# Altium schematic sheet Arduino-8x8 RGB Matrix_1.SchDoc
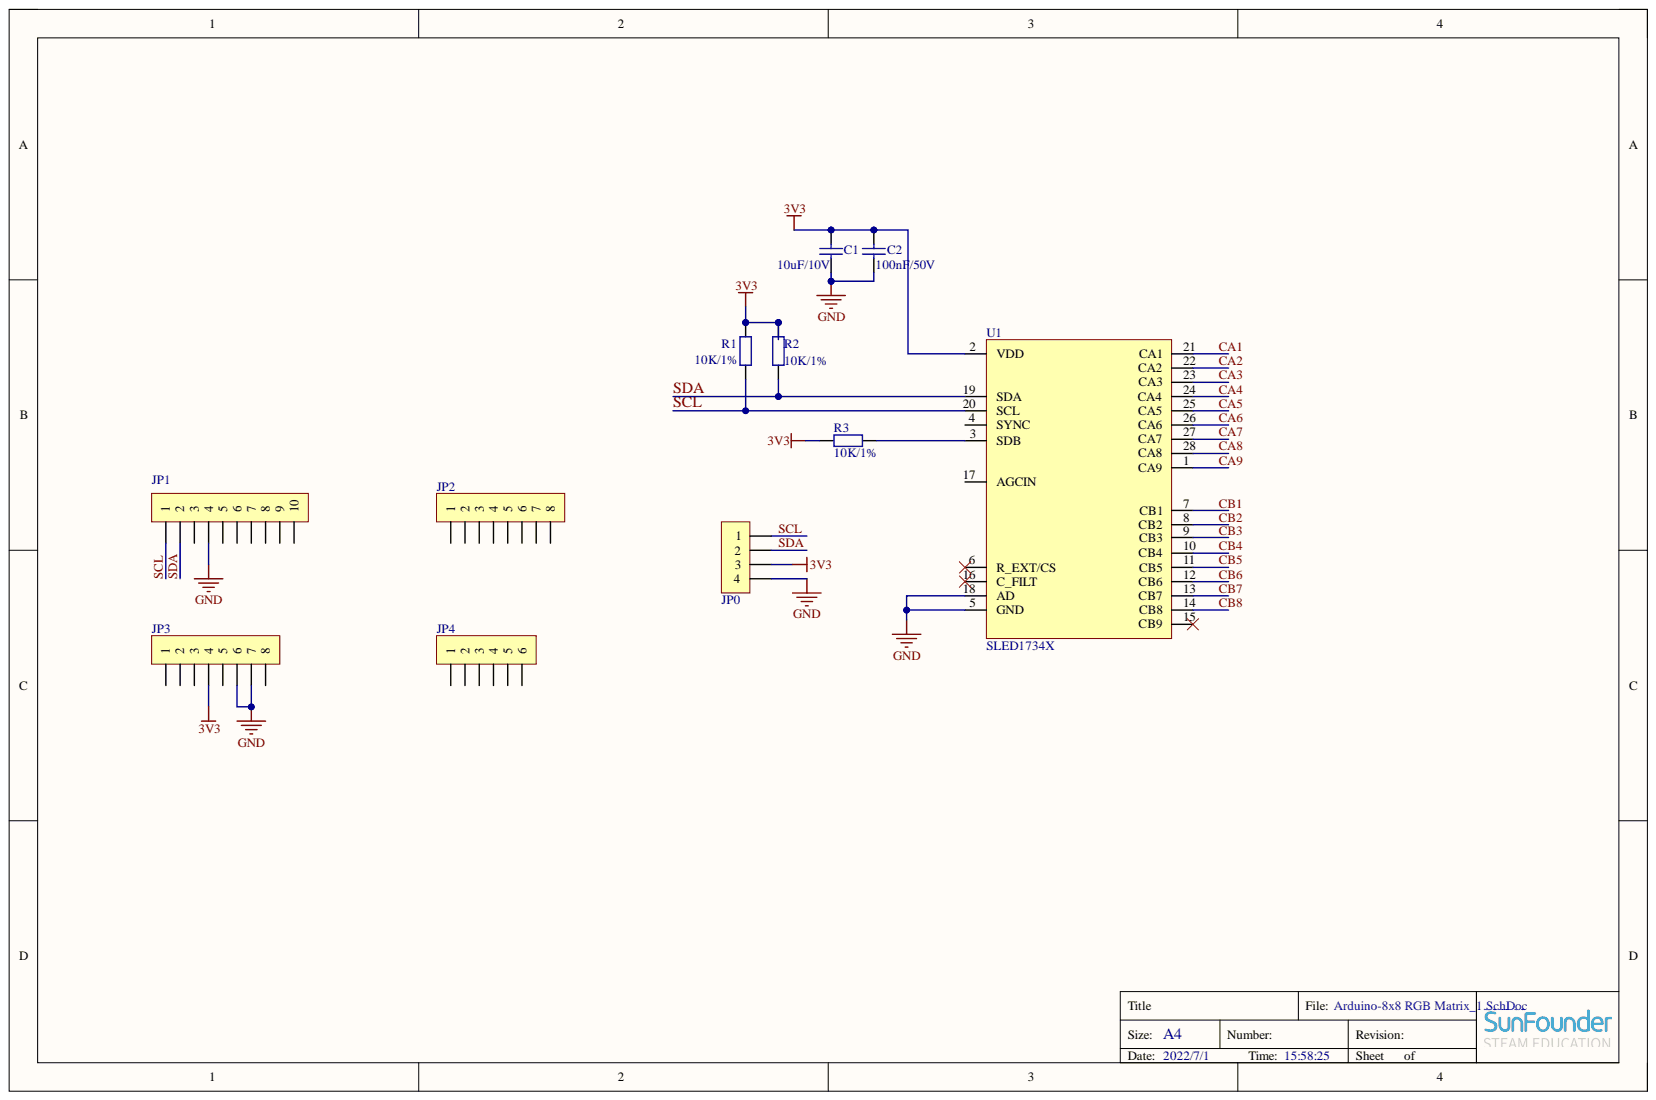
[diagram p0: Arduino-8x8 RGB Matrix_1.SchDoc]

## Parts
C1  10uF/10V  [0603_C]
C2  100nF/50V  [0603_C]
JP0  HDR-1X4  [SH1.0-4P-WS]  (value not drawn)
JP1  HDR-1X10  [HDR2.54-LI-10P]  (value not drawn)
JP2  HDR-1X8  [HDR2.54-LI-8P]  (value not drawn)
JP3  HDR-1X8  [HDR2.54-LI-8P]  (value not drawn)
JP4  HDR-1X6  [HDR2.54-LI-6P]  (value not drawn)
R1  10K/1%  [0603_R]
R2  10K/1%  [0603_R]
R3  10K/1%  [0603_R]
U1  SLED1734X  [SSOP-28_208mil]

## Nets
3V3: C1.2 C2.2 JP0.3 JP3.4 R1.2 R2.2 R3.2 U1.2(VDD)
GND: C1.1 C2.1 JP0.4 JP1.4 JP3.6 JP3.7 U1.5(GND) U1.18(AD)
CA1: U1.21(CA1)
CA2: U1.22(CA2)
CA3: U1.23(CA3)
CA4: U1.24(CA4)
CA5: U1.25(CA5)
CA6: U1.26(CA6)
CA7: U1.27(CA7)
CA8: U1.28(CA8)
CA9: U1.1(CA9)
CB1: U1.7(CB1)
CB2: U1.8(CB2)
CB3: U1.9(CB3)
CB4: U1.10(CB4)
CB5: U1.11(CB5)
CB6: U1.12(CB6)
CB7: U1.13(CB7)
CB8: U1.14(CB8)
SCL: JP0.1 JP1.1 R1.1 U1.20(SCL)
SDA: JP0.2 JP1.2 R2.1 U1.19(SDA)
NetR3_1: R3.1 U1.3(SDB)

Not connected: JP1 3 5 6 7 8 9 10; JP2 1 2 3 4 5 6 7 8; JP3 1 2 3 5 8; JP4 1 2 3 4 5 6; U1 4(SYNC) 6(R_EXT/CS) 15(CB9) 16(C_FILT) 17(AGCIN)

## Library data of the parts
C1 C2 = 0603_C: 贴片电容; Component Kind: Standard; ComponentLink1Description: 供应商链接; ComponentLink1URL: http://www.szlcsc.com/product/details_20411.html; Package: 0603; Supplier: LC; SuppliersPartNumber: C19702; Library Name: RPI_8x8RGB dot matrix screen.SchLib
JP0 = Header 4: 4P接插件; 版权归属: 源创客; BOM名称: 4P接插件; Component Kind: Standard; Library Name: ESP32 HAT.SchLib; 学习资源: www.ooocke.com
JP1 = Header 10: 10P接插件; 版权归属: 源创客; BOM名称: 10P接插件; Component Kind: Standard; Library Name: Schlib.SchLib; 学习资源: www.ooocke.com
JP2 JP3 = Header 8: 8P接插件; 版权归属: 源创客; BOM名称: 8P接插件; Component Kind: Standard; Library Name: Schlib.SchLib; 学习资源: www.ooocke.com
JP4 = Header 6: 6P接插件; 版权归属: 源创客; BOM名称: 6P接插件; Component Kind: Standard; Library Name: Schlib.SchLib; 学习资源: www.ooocke.com
R1-R3 = 0603_R: 贴片电阻; Component Kind: Standard; ComponentLink1Description: 供应商链接; ComponentLink1URL: http://www.szlcsc.com/product/details_23889.html; Package: 0603; Supplier: LC; SuppliersPartNumber: C23162; Library Name: RPI_8x8RGB dot matrix screen.SchLib
U1 = SLED1734X: Component Kind: Standard; Library Name: RGB dot matrix screen.SchLib
